# Altium schematic sheet IO.SchDoc
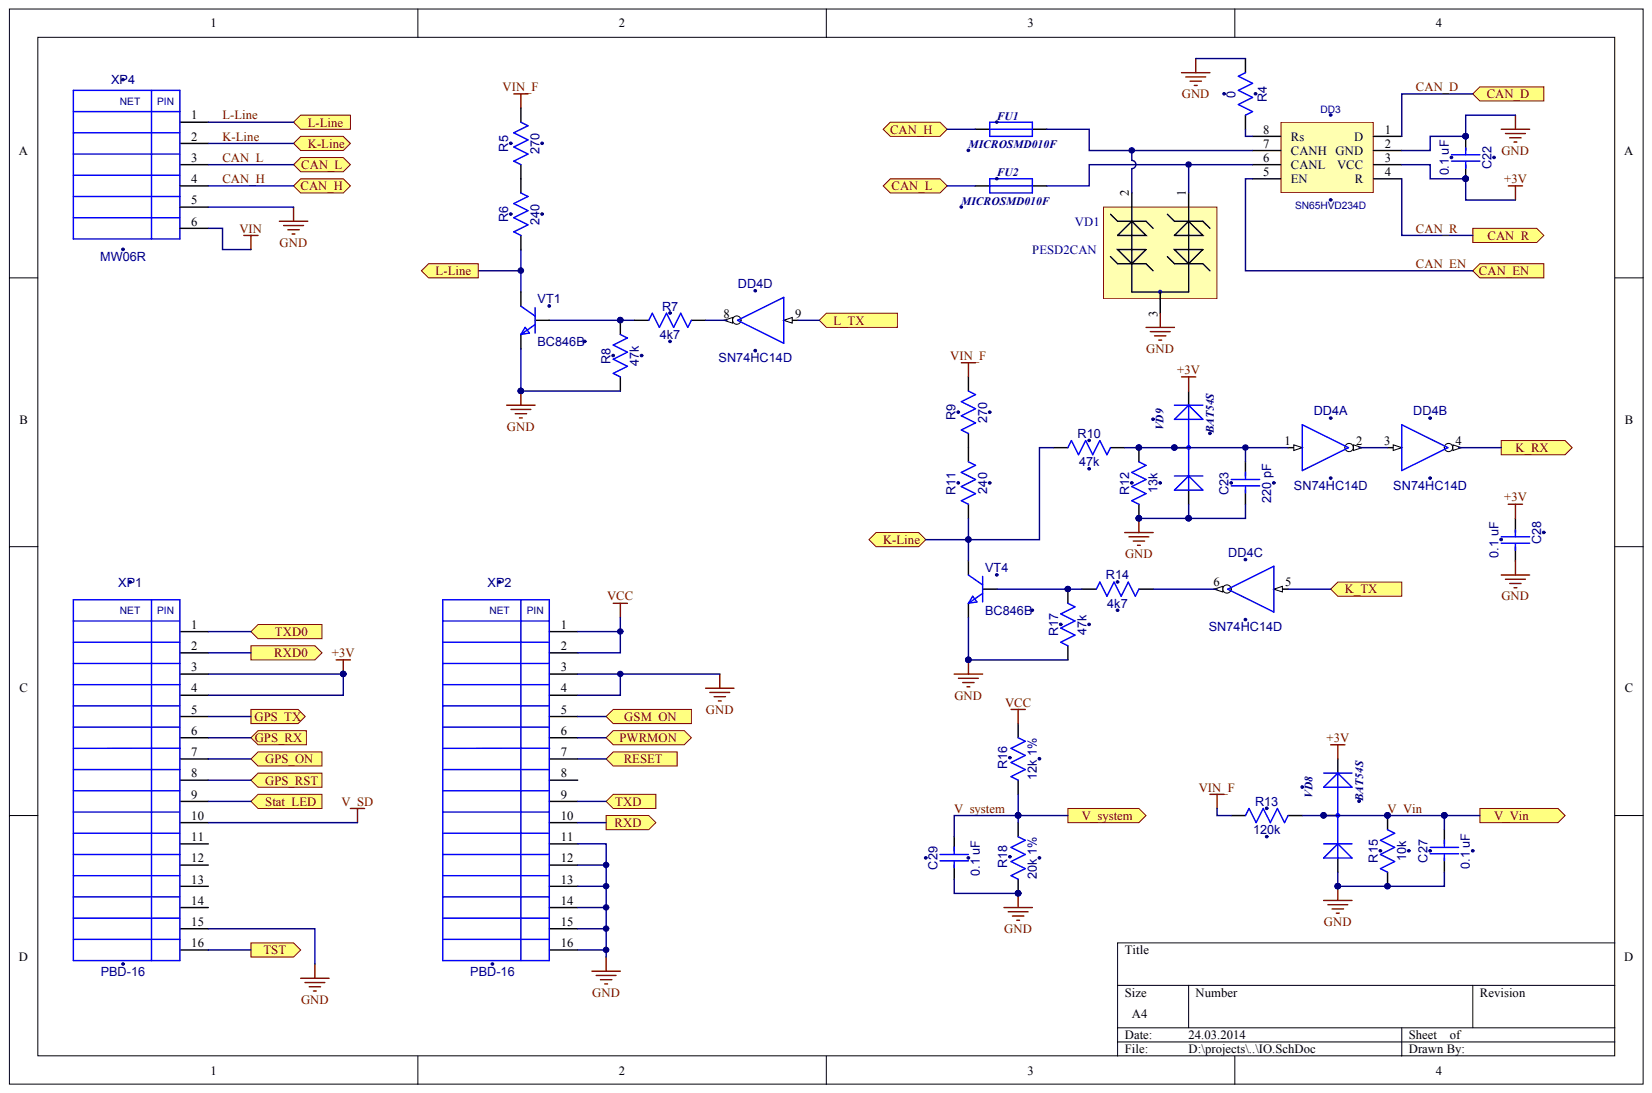
[diagram p0: IO.SchDoc]

## Parts
C22  0.1 uF
C23  220 pF
C27  0.1 uF
C28  0.1 uF
C29  0.1 uF
DD3  SN65HVD234D
DD4  SN74HC14D  (parts A,B,C,D)
FU1  MICROSMD010F
FU2  MICROSMD010F
R4  0
R5  270
R6  240
R7  4k7
R8  47k
R9  270
R10  47k
R11  240
R12  13k
R13  120k
R14  4k7
R15  10k
R16  12k 1%
R17  47k
R18  20k 1%
VD1  PESD2CAN
VD8  BAT54S
VD9  BAT54S
VT1  BC846B
VT4  BC846B
XP1  PBD-16
XP2  PBD-16
XP4  MW06R

## Ports
CAN_D, CAN_EN, CAN_H, CAN_L, CAN_R, GPS_ON, GPS_RST, GPS_RX, GPS_TX, GSM_ON, K-LINE, K_RX, K_TX, L-LINE, L_TX, PWRMON, RESET, RXD, RXD0, STAT_LED, TST, TXD, TXD0, V_SYSTEM, V_VIN

## Nets
+3V: C22.1 C28.2 DD3.3(VCC) DD4.14 VD8.2 VD9.2 XP1.3 XP1.4
GND: C22.2 C23.2 C27.2 C28.1 C29.2 DD3.2(GND) DD4.7 R4.2 R8.2 R12.2 R15.2 R17.2 R18.1 VD1.3 VD8.1 VD9.1 VT1.2 VT4.2 XP1.15 XP2.3 XP2.4 XP2.11 XP2.12 XP2.13 XP2.14 XP2.15 XP2.16 XP4.5
V_SD: XP1.10
VCC: R16.2 XP2.1 XP2.2
VIN: XP4.6
VIN_F: R5.2 R9.2 R13.2
CAN_D: DD3.1(D) ; port CAN_D
CAN_EN: DD3.5(EN) ; port CAN_EN
CAN_H: FU1.1 XP4.4 ; port CAN_H
CAN_L: FU2.1 XP4.3 ; port CAN_L
CAN_R: DD3.4(R) ; port CAN_R
GPS_ON: XP1.7 ; port GPS_ON
GPS_RST: XP1.8 ; port GPS_RST
GPS_RX: XP1.6 ; port GPS_RX
GPS_TX: XP1.5 ; port GPS_TX
GSM_ON: XP2.5 ; port GSM_ON
K-LINE: R10.2 R11.1 VT4.3 XP4.2 ; port K-LINE
K_RX: DD4.4 ; port K_RX
K_TX: DD4.5 ; port K_TX
L-LINE: R6.1 VT1.3 XP4.1 ; port L-LINE
L_TX: DD4.9 ; port L_TX
PWRMON: XP2.6 ; port PWRMON
RESET: XP2.7 ; port RESET
RXD: XP2.10 ; port RXD
RXD0: XP1.2 ; port RXD0
TST: XP1.16 ; port TST
TXD: XP2.9 ; port TXD
TXD0: XP1.1 ; port TXD0
V_SYSTEM: C29.1 R16.1 R18.2 ; port V_SYSTEM
V_VIN: C27.1 R13.1 R15.1 VD8.3 ; port V_VIN
NetC23_1: C23.1 DD4.1 R10.1 R12.1 VD9.3
NetDD3_6: DD3.6(CANL) FU2.2 VD1.1
NetDD3_7: DD3.7(CANH) FU1.2 VD1.2
NetDD3_8: DD3.8(Rs) R4.1
NetDD4_2: DD4.2 DD4.3
NetDD4_6: DD4.6 R14.1
NetDD4_8: DD4.8 R7.1
NetR5_1: R5.1 R6.2
NetR7_2: R7.2 R8.1 VT1.1
NetR9_1: R9.1 R11.2
NetR14_2: R14.2 R17.1 VT4.1
NetXP1_9: XP1.9 ; port STAT_LED

Not connected: XP1 11 12 13 14; XP2 8

## Library data of the parts
VD8 VD9 = (no symbol name): ComponentField6: Published 8-Jun-2000, Modified 11-July-2000: SIM Model Added.; Value: *
VT1 VT4 = (no symbol name): OriginalName: BC846BLT1; Value: {Value}; ComponentField7: Published 29-Sep-2000
C22 C23 C27-C29 DD3 DD4 FU1 FU2 R4-R18 VD1 XP1 XP2 XP4 = (no symbol name)
  C22 C27-C29: Value: 0.1 uF
  C23: Value: 220 pF
  DD3 DD4 XP1 XP2 XP4: Value: *
  FU1 FU2: Value: MICROSMD010F
  R4: Value: 0
  R5 R9: Value: 270
  R6 R11: Value: 240
  R7 R14: Value: 4k7
  R8 R10 R17: Value: 47k
  R12: Value: 13k
  R13: Value: 120k
  R15: Value: 10k
  R16: Value: 12k 1%
  R18: Value: 20k 1%
